# Altium schematic sheet 1.WIZ750SR_EVB_DATA.SchDoc
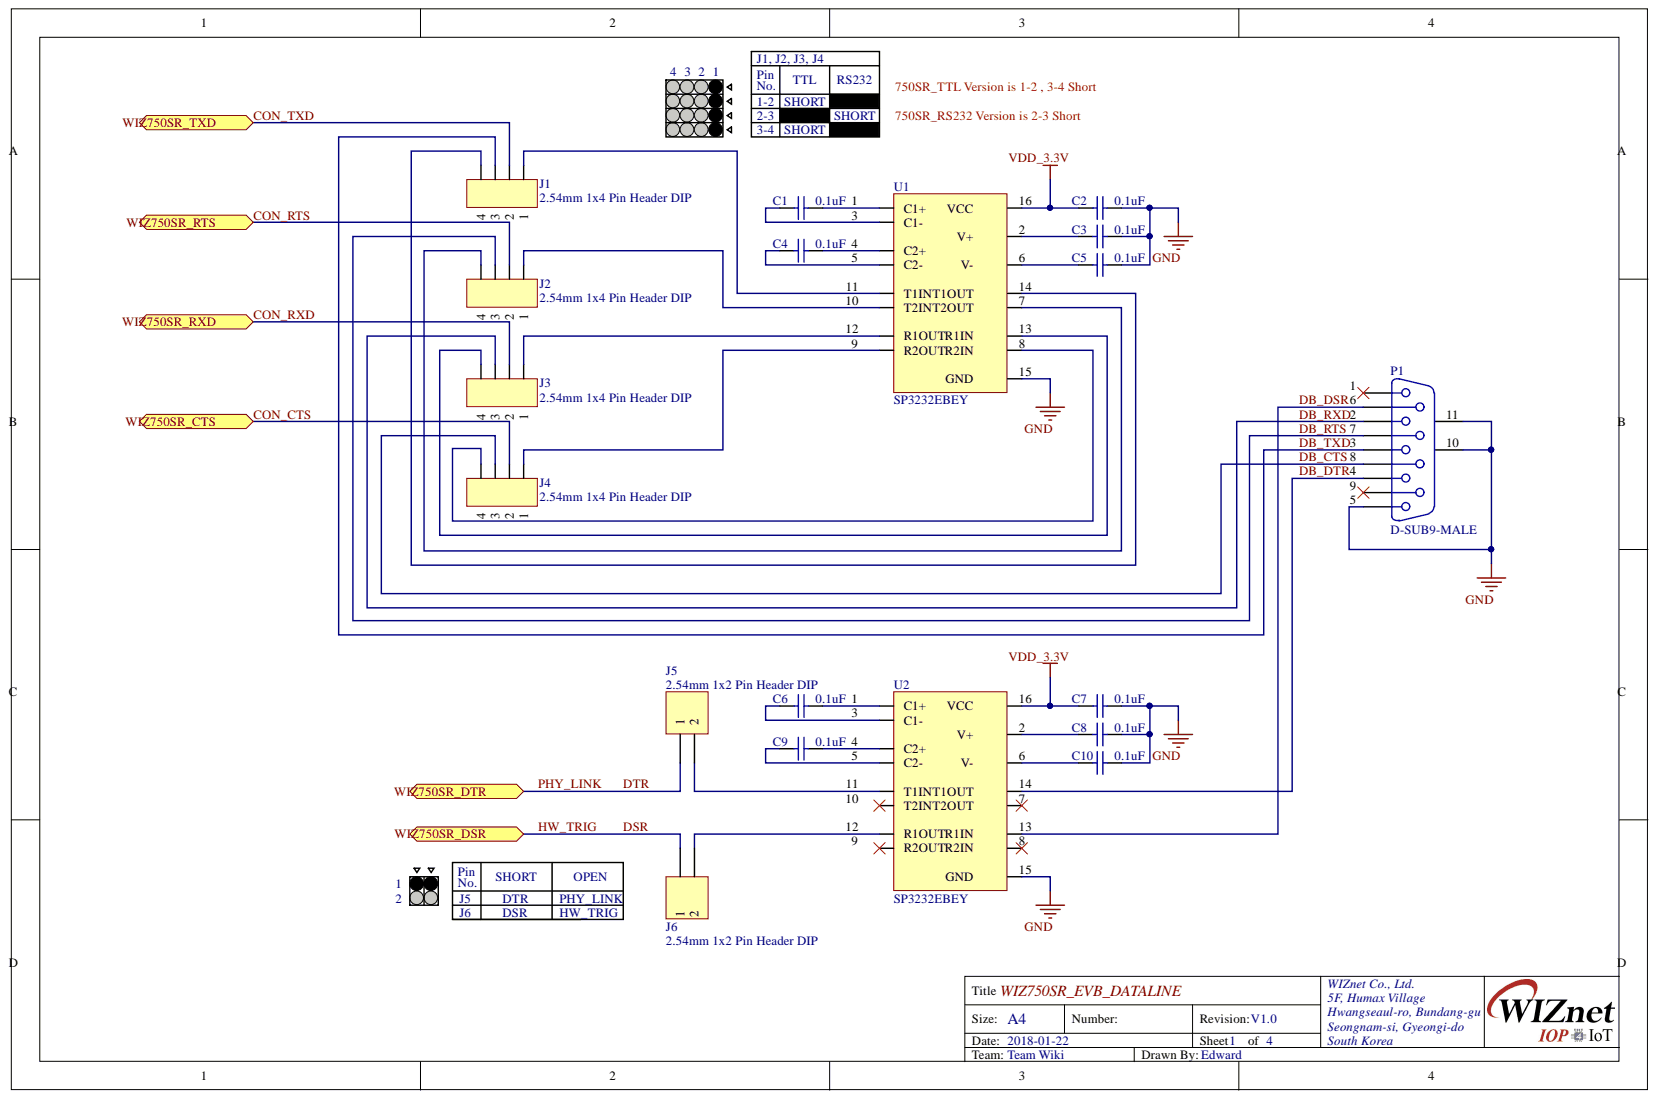
[diagram p0: 1.WIZ750SR_EVB_DATA.SchDoc]

## Parts
C1  0.1uF  [CAP-0603]
C2  0.1uF  [CAP-0603]
C3  0.1uF  [CAP-0603]
C4  0.1uF  [CAP-0603]
C5  0.1uF  [CAP-0603]
C6  0.1uF  [CAP-0603]
C7  0.1uF  [CAP-0603]
C8  0.1uF  [CAP-0603]
C9  0.1uF  [CAP-0603]
C10  0.1uF  [CAP-0603]
J1  2.54mm 1x4 Pin Header DIP  [IDC-1X4]
J2  2.54mm 1x4 Pin Header DIP  [IDC-1X4]
J3  2.54mm 1x4 Pin Header DIP  [IDC-1X4]
J4  2.54mm 1x4 Pin Header DIP  [IDC-1X4]
J5  2.54mm 1x2 Pin Header DIP  [IDC-1X2]
J6  2.54mm 1x2 Pin Header DIP  [IDC-1X2]
P1  D-SUB9-MALE  [D-SUB9-MALE]
U1  SP3232EBEY  [SP3232EBEY-TSSOP16]
U2  SP3232EBEY  [SP3232EBEY-TSSOP16]

## Ports
WIZ750SR_CTS, WIZ750SR_DSR, WIZ750SR_DTR, WIZ750SR_RTS, WIZ750SR_RXD, WIZ750SR_TXD

## Nets
GND: C2.2 C3.2 C5.2 C7.2 C8.2 C10.2 P1.5 P1.10 P1.11 U1.15 U2.15
VDD_3.3V: C2.1 C7.1 U1.16 U2.16
CON_CTS: J4.2 ; port WIZ750SR_CTS
CON_RTS: J2.2 ; port WIZ750SR_RTS
CON_RXD: J3.2 ; port WIZ750SR_RXD
CON_TXD: J1.2 ; port WIZ750SR_TXD
DB_CTS: J4.3 P1.8
DB_DSR: P1.6 U2.13
DB_DTR: P1.4 U2.14
DB_RTS: J2.3 P1.7
DB_RXD: J3.3 P1.2
DB_TXD: J1.3 P1.3
DSR: J6.1 ; port WIZ750SR_DSR
DTR: J5.1 ; port WIZ750SR_DTR
NetC1_1: C1.1 U1.3(C1-)
NetC1_2: C1.2 U1.1(C1+)
NetC3_1: C3.1 U1.2
NetC4_1: C4.1 U1.5(C2-)
NetC4_2: C4.2 U1.4(C2+)
NetC5_1: C5.1 U1.6
NetC6_1: C6.1 U2.3(C1-)
NetC6_2: C6.2 U2.1(C1+)
NetC8_1: C8.1 U2.2
NetC9_1: C9.1 U2.5(C2-)
NetC9_2: C9.2 U2.4(C2+)
NetC10_1: C10.1 U2.6
NetJ1_1: J1.1 U1.11
NetJ1_4: J1.4 U1.14
NetJ2_1: J2.1 U1.10
NetJ2_4: J2.4 U1.7
NetJ3_1: J3.1 U1.12
NetJ3_4: J3.4 U1.13
NetJ4_1: J4.1 U1.9
NetJ4_4: J4.4 U1.8
NetJ5_2: J5.2 U2.11
NetJ6_2: J6.2 U2.12

Not connected: P1 1 9; U2 7 8 9 10

## Library data of the parts
C1-C10 = CAP-0603: Ceramic CAP 0603(1608); Component Kind: Standard; Library Name: Scott_lib.IntLib
J5 J6 = Header 2: Header, 2-Pin; Component Kind: Standard; LatestRevisionDate: 17-Jul-2002; LatestRevisionNote: Re-released for DXP Platform.; Signal Integrity: Connector; Library Name: Edward.IntLib; Publisher: Altium Limited
P1 = D-SUB9-MALE: D-SUB-9, MALE,  Right Angle; Gender: Receptacle; PackageDocument: O; Positions: 9; Component Kind: Standard; DatasheetDocument: 1-Sep-1999; LatestRevisionDate: 17-Jul-2002; LatestRevisionNote: Re-released for DXP Platform.; Orientation: Right Angle; PackageReference: 788750; Published: 15-Jun-2001; Library Name: Scott_lib.IntLib; Publisher: Altium Limited
U1 U2 = SP3232EBEY: True +3.0V to +5.5V RS-232 Transceivers; Component Kind: Standard; Library Name: Scott_lib.IntLib
J1-J4 = 2.54mm 1x4 Pin Header DIP: Component Kind: Standard; Description: 2.54mm 1x4 Pin Header DIP; Library Name: Scott_lib.IntLib
